# Altium schematic sheet pay-optical.SchDoc
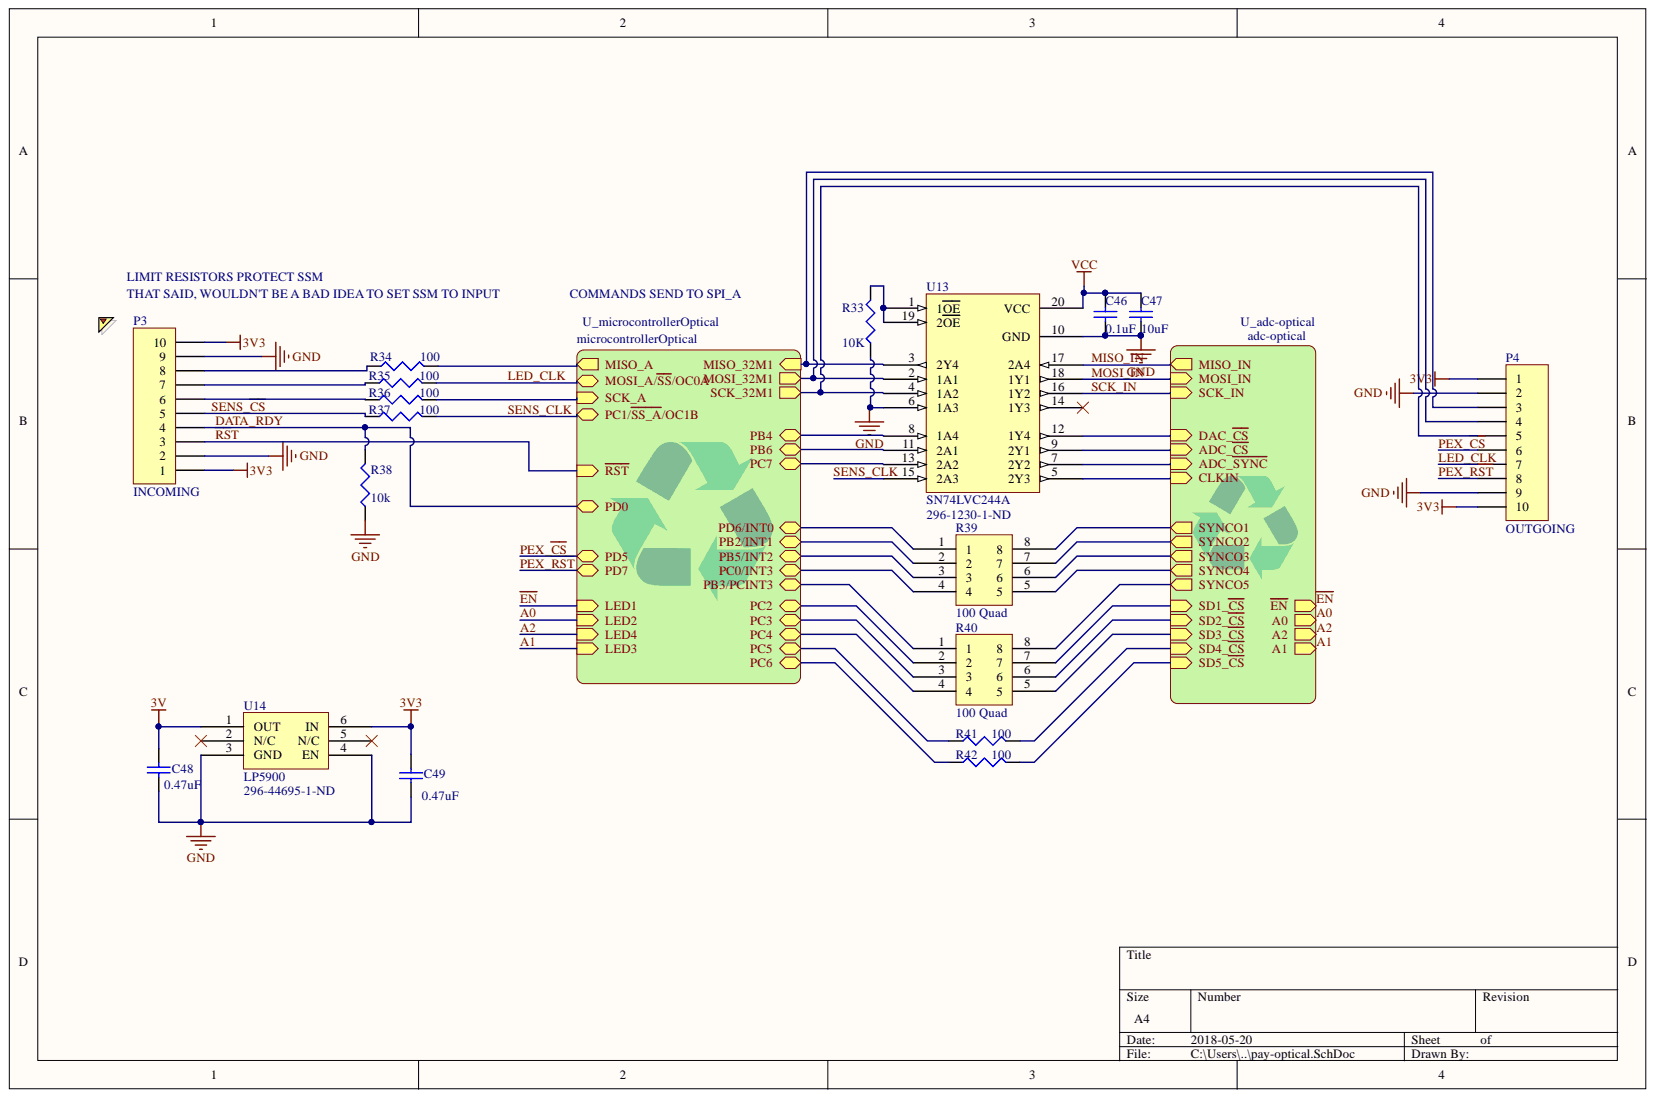
[diagram p0: pay-optical.SchDoc]

## Parts
C46  value 0.1uF  [C1206]  (hidden comment: Cap Semi)
C47  value 10uF  [C1206]  (hidden comment: Cap Semi)
C48  value 0.47uF  [C1206]  (hidden comment: Cap Semi)
C49  value 0.47uF  [C1206]  (hidden comment: Cap Semi)
P3  INCOMING  [HDR1X10]
P4  OUTGOING  [HDR1X10]
R33  value 10K  [J1-0603]  (hidden comment: Res3)
R34  value 100  [J1-0603]  (hidden comment: Res3)
R35  value 100  [J1-0603]  (hidden comment: Res3)
R36  value 100  [J1-0603]  (hidden comment: Res3)
R37  value 100  [J1-0603]  (hidden comment: Res3)
R38  value 10k  [J1-0603]  (hidden comment: Res3)
R39  100 Quad
R40  100 Quad
R41  value 100  [J1-0603]  (hidden comment: Res3)
R42  value 100  [J1-0603]  (hidden comment: Res3)
U13  SN74LVC244A  [SOP65P650X440-20N]
U14  LP5900  Supplier Part Number 1: 296-44695-1-ND

## Nets
3V: C48.2 U14.1(OUT)
3V3: C49.2 P3.1 P3.10 P4.1 P4.10 U14.6(IN)
GND: C46.1 C47.1 C48.1 C49.1 P3.2 P3.9 P4.2 P4.9 R33.1 R38.1 U13.6(1A3) U13.10(GND) U14.3(GND) U14.4(EN)
VCC: C46.2 C47.2 U13.20(VCC)
DATA_RDY: P3.4 R38.2
LED_CLK: P4.7 R35.2
MISO_IN: U13.17(2A4)
MOSI_IN: U13.18(1Y1)
PEX_~{CS}: P4.6
PEX_~{RST}: P4.8
~{RS}T: P3.3
SCK_IN: U13.16(1Y2)
SENS_~{CS}: P3.5 R37.1
SENS_CLK: R37.2 U13.15(2A3)
NetP3_6: P3.6 R36.1
NetP3_7: P3.7 R35.1
NetP3_8: P3.8 R34.1
NetP4_3: P4.3 U13.3(2Y4)
NetP4_4: P4.4 U13.2(1A1)
NetP4_5: P4.5 U13.4(1A2)
NetR33_2: R33.2 U13.1(1OE) U13.19(2OE)
NetR34_2: R34.2
NetR36_2: R36.2
NetR39_1: R39.1
NetR39_2: R39.2
NetR39_3: R39.3
NetR39_4: R39.4
NetR39_5: R39.5
NetR39_6: R39.6
NetR39_7: R39.7
NetR39_8: R39.8
NetR40_1: R40.1
NetR40_2: R40.2
NetR40_3: R40.3
NetR40_4: R40.4
NetR40_5: R40.5
NetR40_6: R40.6
NetR40_7: R40.7
NetR40_8: R40.8
NetR41_1: R41.1
NetR41_2: R41.2
NetR42_1: R42.1
NetR42_2: R42.2
NetU13_5: U13.5(2Y3)
NetU13_7: U13.7(2Y2)
NetU13_8: U13.8(1A4)
NetU13_9: U13.9(2Y1)
NetU13_11: U13.11(2A1)
NetU13_12: U13.12(1Y4)
NetU13_13: U13.13(2A2)
NetU13_14: U13.14(1Y3)
NetU14_2: U14.2(N/C)
NetU14_5: U14.5(N/C)
A0:
A1:
A2:
~{EN}:

## Library data of the parts
C46-C49 = Cap Semi: Capacitor (Semiconductor SIM Model); Component Kind: Standard; LatestRevisionDate: 29-May-2009; LatestRevisionNote: IPC-7351 Footprint Added.; Library Name: Miscellaneous Devices.IntLib; PackageDescription: Chip Capacitor; PackageReference: C1206; Published: 8-Jun-2000; Signal Integrity: Cap Semi; Simulation: CAP; Publisher: Altium Limited
P3 P4 = Header 10: Header, 10-Pin; Component Kind: Standard; LatestRevisionDate: 17-Jul-2002; LatestRevisionNote: Re-released for DXP Platform.; Library Name: Miscellaneous Connectors.IntLib; Signal Integrity: Connector; Publisher: Altium Limited
R33-R38 R41 R42 = Res3: Resistor; Component Kind: Standard; LatestRevisionDate: 29-May-2009; LatestRevisionNote: IPC-7351 Footprint Added.; Library Name: Miscellaneous Devices.IntLib; PackageDescription: Chip Resistor; PackageReference: J1-0603; Published: 8-Jun-2000; Signal Integrity: Res; Simulation: RESISTOR; Publisher: Altium Limited
R39 R40 = Res Quad Pack: Quad pack resistor; Component Kind: Standard; Library Name: PAY.SchLib
U13 = SN74LVC244A: Octal buffer or driver with 3-state outputs.; Component Kind: Standard; Library Name: ICs.SchLib; Supplier 1: Digi-Key; Supplier Part Number 1: 296-1230-1-ND
U14 = LP5900: Low noise 3V low dropout regulator for analog and RF applications.; Component Kind: Standard; Supplier 1: Digi-Key; Library Name: PAY.SchLib
